# Altium schematic sheet cpu.SchDoc(cpu)
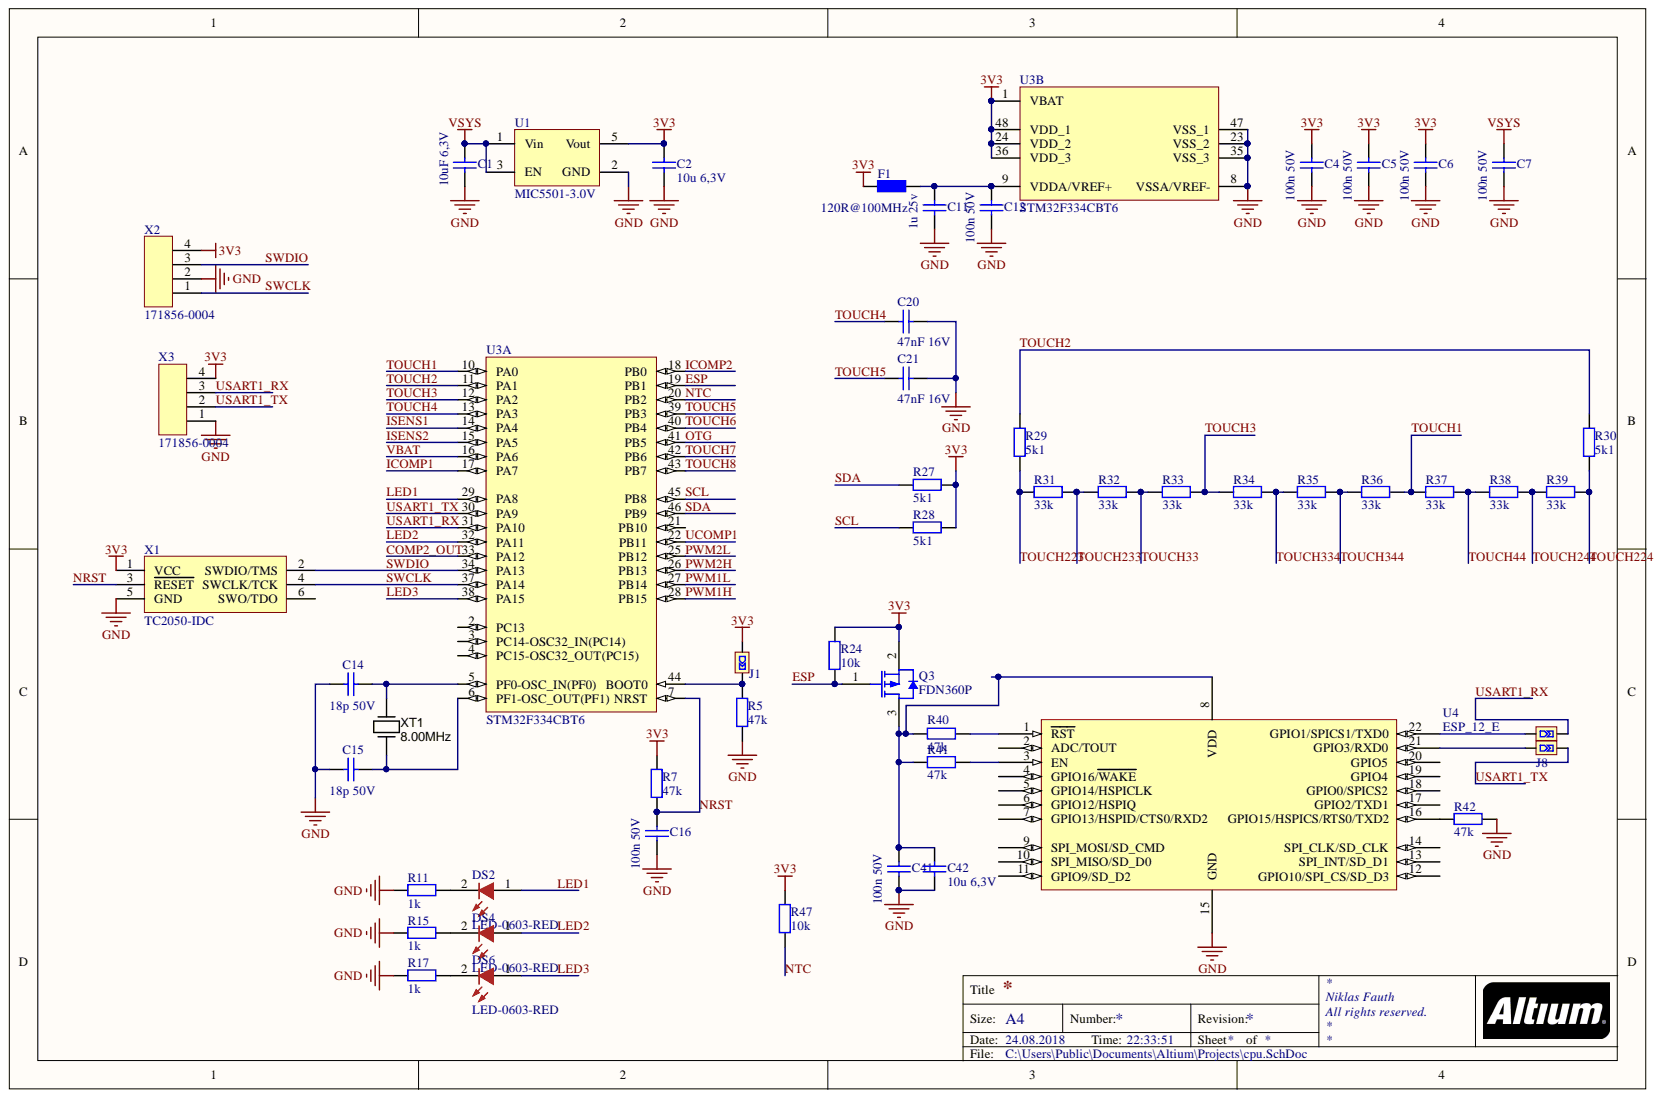
[diagram p0: cpu.SchDoc]

## Parts
C1  10uF 6,3V  [C-0603-H]
C2  10u 6,3V  [C-0603-H]
C4  100n 50V  [C-0603-H]
C5  100n 50V  [C-0603-H]
C6  100n 50V  [C-0603-H]
C7  100n 50V  [C-0603-H]
C11  1u 25v  [C-0603-H]
C12  100n 50V  [C-0603-H]
C14  18p 50V  [C-0603-H]
C15  18p 50V  [C-0603-H]
C16  100n 50V  [C-0603-H]
C20  47nF 16V  [C-0603-H]
C21  47nF 16V  [C-0603-H]
C41  100n 50V  [C-0603-H]
C42  10u 6,3V  [C-0603-H]
DS2  LED-0603-RED  [LED-0603-RED]
DS4  LED-0603-RED  [LED-0603-RED]
DS6  LED-0603-RED  [LED-0603-RED]
F1  120R@100MHz  [R-0805-H]
J1  jumper  [JUMPER 1-1]  (value not drawn)
J7  jumper  [JUMPER 1-1]  (value not drawn)
J8  jumper  [JUMPER 1-1]  (value not drawn)
Q3  FDN360P  [ONSC-SOT-23-3-527AG-01_L]
R5  47k  [R-0603-H]
R7  47k  [R-0603-H]
R11  1k  [R-0603-M]
R15  1k  [R-0603-M]
R17  1k  [R-0603-M]
R24  10k  [R-0603-H]
R27  5k1  [R-0603-H]
R28  5k1  [R-0603-H]
R29  5k1  [R-0603-H]
R30  5k1  [R-0603-H]
R31  33k  [R-0603-M]
R32  33k  [R-0603-M]
R33  33k  [R-0603-M]
R34  33k  [R-0603-M]
R35  33k  [R-0603-M]
R36  33k  [R-0603-M]
R37  33k  [R-0603-M]
R38  33k  [R-0603-M]
R39  33k  [R-0603-M]
R40  47k  [R-0603-H]
R41  47k  [R-0603-H]
R42  47k  [R-0603-H]
R47  10k  [R-0603-H]
U1  MIC5501-3.0V  [SOT23-5]
U3  STM32F334CBT6  [STM-LQFP48_N]  (parts A,B)
U4  ESP_12_E  [ESP_12_E]
X1  TC2050-IDC  [TC2030-IDC-NL]
X2  171856-0004  [PINHEAD-MALE-4]
X3  171856-0004  [PINHEAD-MALE-4]
XT1  8.00MHz  [CRYSTAL_HC49-US-SM]

## Nets
3V3: C2.2 C4.2 C5.2 C6.2 F1.1 J1.1 Q3.2 R7.2 R24.1 R27.2 R28.2 R47.1 U1.5(Vout) U3.1(VBAT) U3.24(VDD_2) U3.36(VDD_3) U3.48(VDD_1) X1.1(VCC) X2.4 X3.4
GND: C1.1 C2.1 C4.1 C5.1 C6.1 C7.1 C11.1 C12.1 C14.2 C15.2 C16.1 C20.2 C21.2 C41.1 C42.1 R5.1 R11.2 R15.2 R17.2 R42.2 U1.2(GND) U3.8(VSSA/VREF-) U3.23(VSS_2) U3.35(VSS_3) U3.47(VSS_1) U4.15(GND) X1.5(GND) X2.2 X3.1
VSYS: C1.2 C7.2 U1.1(Vin) U1.3(EN)
COMP2_OUT: U3.33(PA12)
ESP: Q3.1 R24.2 U3.19(PB1)
ICOMP1: U3.17(PA7)
ICOMP2: U3.18(PB0)
ISENS1: U3.14(PA4)
ISENS2: U3.15(PA5)
LED1: DS2.1 U3.29(PA8)
LED2: DS4.1 U3.32(PA11)
LED3: DS6.1 U3.38(PA15)
NRST: C16.2 R7.1 U3.7(NRST) X1.3(RESET)
NTC: R47.2 U3.20(PB2)
OTG: U3.41(PB5)
PWM1H: U3.28(PB15)
PWM1L: U3.27(PB14)
PWM2H: U3.26(PB13)
PWM2L: U3.25(PB12)
SCL: R28.1 U3.45(PB8)
SDA: R27.1 U3.46(PB9)
SWCLK: U3.37(PA14) X1.4(SWCLK/TCK) X2.1
SWDIO: U3.34(PA13) X1.2(SWDIO/TMS) X2.3
TOUCH1: R36.2 R37.1 U3.10(PA0)
TOUCH2: R29.2 R30.2 U3.11(PA1)
TOUCH3: R33.2 R34.1 U3.12(PA2)
TOUCH4: C20.1 U3.13(PA3)
TOUCH5: C21.1 U3.39(PB3)
TOUCH6: U3.40(PB4)
TOUCH7: U3.42(PB6)
TOUCH8: U3.43(PB7)
TOUCH33: R32.2 R33.1
TOUCH44: R37.2 R38.1
TOUCH223: R29.1 R31.1
TOUCH224: R30.1 R39.2
TOUCH233: R31.2 R32.1
TOUCH244: R38.2 R39.1
TOUCH334: R34.2 R35.1
TOUCH344: R35.2 R36.1
UCOMP1: U3.22(PB11)
USART1_RX: J7.2 U3.31(PA10) X3.3
USART1_TX: J8.2 U3.30(PA9) X3.2
VBAT: U3.16(PA6)
NetC11_2: C11.2 C12.2 F1.2 U3.9(VDDA/VREF+)
NetC14_1: C14.1 U3.5(PF0-OSC_IN(PF0)) XT1.2
NetC15_1: C15.1 U3.6(PF1-OSC_OUT(PF1)) XT1.1
NetC41_2: C41.2 C42.2 Q3.3 R40.1 R41.1 U4.8(VDD)
NetDS2_2: DS2.2 R11.1
NetDS4_2: DS4.2 R15.1
NetDS6_2: DS6.2 R17.1
NetJ1_2: J1.2 R5.2 U3.44(BOOT0)
NetJ7_1: J7.1 U4.22(GPIO1/SPICS1/TXD0)
NetJ8_1: J8.1 U4.21(GPIO3/RXD0)
NetR40_2: R40.2 U4.1(RST)
NetR41_2: R41.2 U4.3(EN)
NetR42_1: R42.1 U4.16(GPIO15/HSPICS/RTS0/TXD2)

Not connected: U3 2(PC13) 3(PC14-OSC32_IN(PC14)) 4(PC15-OSC32_OUT(PC15)) 21(PB10); U4 2(ADC/TOUT) 4(GPIO16/WAKE) 5(GPIO14/HSPICLK) 6(GPIO12/HSPIQ) 7(GPIO13/HSPID/CTS0/RXD2) 9(SPI_MOSI/SD_CMD) 10(SPI_MISO/SD_D0) 11(GPIO9/SD_D2) 12(GPIO10/SPI_CS/SD_D3) 13(SPI_INT/SD_D1) 14(SPI_CLK/SD_CLK) 17(GPIO2/TXD1) 18(GPIO0/SPICS2) 19(GPIO4) 20(GPIO5); X1 6(SWO/TDO)

## Library data of the parts
C1 C11 = C-0603-1u-25V: Capacitor 1uF 25V; Supplier Part Number 1: 80-C0603C105K3R; Supplier 1: Mouser; Library Name: Passives.IntLib; Component Kind: Standard
C2 C42 = C-0603-10u-6,3V: Capacitor 10uF 6,3V; Supplier Part Number 1: 80-C0603C106M9P; Supplier 1: Mouser; Library Name: Passives.IntLib; Component Kind: Standard
C4-C7 C12 C16 C41 = C-0603-100n-50V: Capacitor 100n 50V; Supplier Part Number 1: 80-C0603C104K5RAUTO; Supplier 1: Mouser; Library Name: Passives.IntLib; Component Kind: Standard
C14 C15 = C-0402-18p-50V: Capacitor 18p 50V; Supplier Part Number 1: 80-C0402C180J5G; Supplier 1: Mouser; Library Name: Passives.IntLib; Component Kind: Standard
C20 C21 = C-0603-4u7-16V: Capacitor 4.7uF 16V; Supplier Part Number 1: 81-GRM188R61C475KAJD; Supplier 1: Mouser; Library Name: Capacitors-0603.SchLib; Component Kind: Standard
F1 = F-0805-120R@100mhz: Ferrite 120R@100MHz; Supplier Part Number 1: 81-DLW21HN121SQ2L; Supplier 1: Mouser; Library Name: Passives.IntLib; Component Kind: Standard
J1 J7 J8 = jumper 1-1: Component Kind: Standard
  J1: Library Name: advanced.SchLib
  J7 J8: Library Name: advanced.IntLib
Q3 = CMP-2000-07455-1: Single P-Channel PowerTrench(R) MOSFET, 30 V, 2 A, -55 to 150 degC, 3-Pin SOT23, RoHS, Tape and Reel; Manufacturer: Fairchild; Voltage Rating (DC): -30V; Turn-On Delay Time: 6ns; Turn-Off Delay Time: 11ns; Threshold Voltage: 20V; Rise Time: 13ns; Rds On Max: 80mOhm; Power Dissipation: 500mW; Package Version: Rev. O, 12/2008; Number of Elements: 1; Input Capacitance: 298pF; Drain to Source Voltage (Vdss): 30V; Drain to Source Resistance: 63mOhm; Current Rating: -2A; Continuous Drain Current (ID): 2A; Case\/Package: SOT-23; Width: 1.4mm; Weight: 0.03g; RoHSCompliant: true; REACH SVHC: NoSVHC; Radiation Hardening: No; Packaging: TapeandReel; Package URL: http://www.onsemi.com/pub_link/Collateral/527AG.PDF; Package Reference: SOT-23-3-527AG-01; Package Quantity: 1; Package Description: 3-Pin Small Outline Transistor, Body 2.92 x 1.3 mm, Pitch 0.95 mm; Number of Pins: 3; Mounting Technology: SurfaceMount; Mount: SurfaceMount; Min Operating Temperature: -55degC; Max Power Dissipation: 500mW; Max Operating Temperature: 150degC; Manufacturer URL: https://www.fairchildsemi.com/; Library Name: Altium Content Vault; Length: 2.92mm; Lead Free: LeadFree; Height: 0.94mm; Gate to Source Voltage (Vgs): 20V; Fall Time: 6ns; Element Configuration: Single; Dual Supply Voltage: -30V; Drain to Source Breakdown Voltage: -30V; Datasheet Version: Rev. F1(W), 05/2003; Datasheet URL: http://www.onsemi.cn/pub/Collateral/FDN360PCN-D.pdf; Contact Plating: Tin; Component Kind: Standard
R5 R7 = R-0603-47k: Resistor 4k7; Supplier Part Number 1: 71-CRCW060347K0FKEB; Supplier 1: Mouser; Library Name: Passives.IntLib; Component Kind: Standard
R11 R15 R17 = R-0603-1k: Resistor 1k; Supplier Part Number 1: 71-CRCW0603-1.0K-E3; Supplier 1: Mouser; Library Name: Passives.IntLib; Component Kind: Standard
R24 R27 R28 R40-R42 R47 = R-0603-10k: Resistor 10k; Supplier Part Number 1: 71-CRCW0603-10K-E3; Supplier 1: Mouser; Library Name: Resistors-0603.SchLib; Component Kind: Standard
R29 R30 = R-0603-5k1: Resistor 5.1k; Supplier Part Number 1: 71-CRCW0603-5.1K-E3; Supplier 1: Mouser; Library Name: Resistors-0603.SchLib; Component Kind: Standard
R31-R39 = R-0603-28k: Resistor 33k; Supplier Part Number 1: 71-CRCW0603-28K-E3; Supplier 1: Mouser; Library Name: Resistors-0603.SchLib; Component Kind: Standard
U1 = MIC5501-3.3V: Supplier Part Number 1: 998-MIC5501-3.0YM5TR; Supplier 1: Mouser; Library Name: advanced.IntLib; Component Kind: Standard
U3 = CMP-0572-00016-2: ARM Cortex-M4 32-bit MCU, 128 KB Flash, 24 KB Internal RAM, 37 I/Os, 48-pin LQFP, -40 to 85 degC, Tray; PartNumber: STM32F302CBT6; Supply Voltage(Vcc) min (V): 2; Operating Frequency(F) (Processor speed) (MHz): 72; Internal RAM Size (kB): 24; I/Os (High Current): 37; FLASH Size (Prog) (kB): 128; D/A Converter: 1x12-bit (1 Channel); PartId: 1; Packing: Tray; PackageVersion: Rev. 6, 06/2013; PackageReference: LQFP48; PackageDescription: 48-Pin Low Profile Quad Flat Package, 7 x 7 mm Body, 0.5 mm Pitch; Mounting Technology: Surface Mount; Library Name: Altium Content Vault; DatasheetVersion: Rev. 6; Core: ARM Cortex-M4; ComponentLink3URL: http://www.st.com/st-web-ui/static/active/en/resource/technical/document/datasheet/DM00058181.pdf; ComponentLink3Description: Package Specification; ComponentLink2URL: http://www.st.com/st-web-ui/static/active/en/resource/technical/document/datasheet/DM00058181.pdf; ComponentLink2Description: Datasheet; ComponentLink1URL: http://www.st.com/web/en/home.html; ComponentLink1Description: Manufacturer URL; Component Kind: Standard
U4 = ESP_12_E: ESP-12 Wi-Fi Module by AI-Thinker; IC: ESP8266-EX; Vendor: Ai-thinker; Library Name: advanced.IntLib; Component Kind: Standard
X1 = TAG-Connect-SWD: TC2050-IDC SWD; Library Name: advanced.SchLib; Component Kind: Standard
X2 X3 = PINHEAD-MALE-4: Library Name: advanced.SchLib; Component Kind: Standard
XT1 = Crystal_6MHz_HC49/US: Resonator Ceramic 6.00 MHz 30ppm; Manufacturer Part Number 1: ATS060BSM-1; Manufacturer 1: CTS-Frequency Controls; SCDType: spec; Parameter Name: 0.169" (4.30mm); Operating Mode: Fundamental; Load Capacitance: 18pF; Frequency Stability: ±50ppm; Frequency: 6MHz; Type: MHz Crystal; Supplier Part Number 1: CTX882CT-ND; Supplier 1: Digi-Key; Size / Dimension: 0.437" L x 0.190" W (11.10mm x 4.83mm); Ratings: 6.00 MHz; Package / Case: HC49/US-SM; Operating Temperature: -20°C ~ 70°C; Mounting Type: Surface Mount; Library Name: PBL - Resonators.SCHLIB; Frequency Tolerance: ±30ppm; ComponentLink1URL: http://www.ctscorp.com/components/Datasheets/008-0309-0.pdf; ComponentLink1Description: http://www.ctscorp.com/components/Datasheets/008-0309-0.pdf; Component Kind: Standard
DS2 DS4 DS6 = LED-0603-RED: Supplier Part Number 1: 859-LTST-C190EKT; Supplier 1: Mouser; Library Name: advanced.SchLib; Component Kind: Standard
